# Altium schematic sheet Power.SchDoc
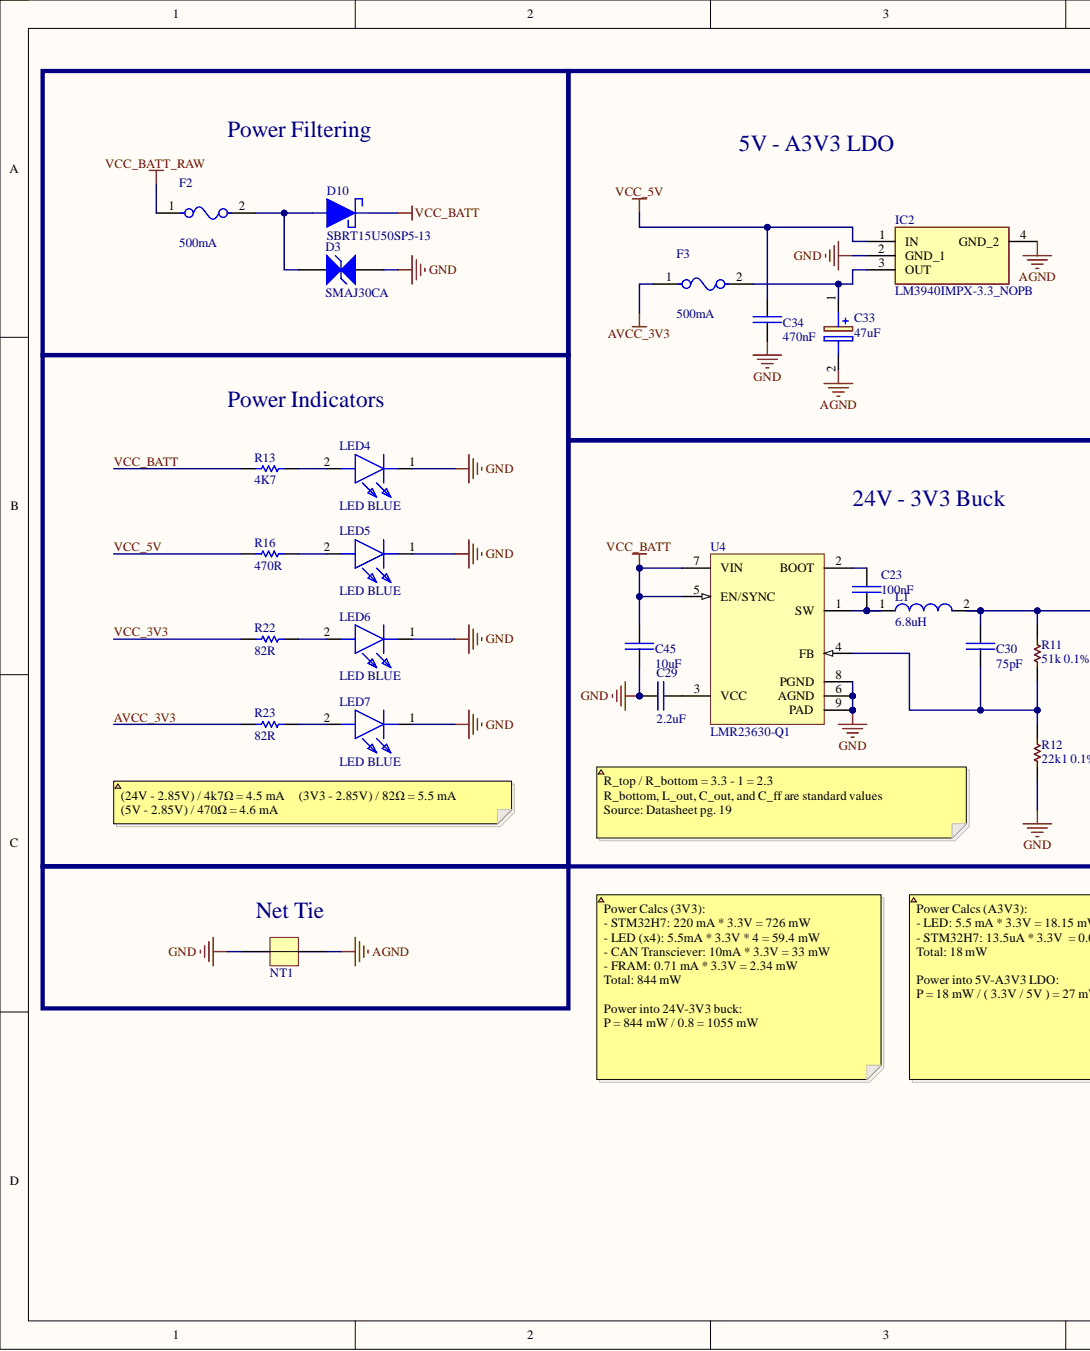
[diagram p0: Power.SchDoc, left]
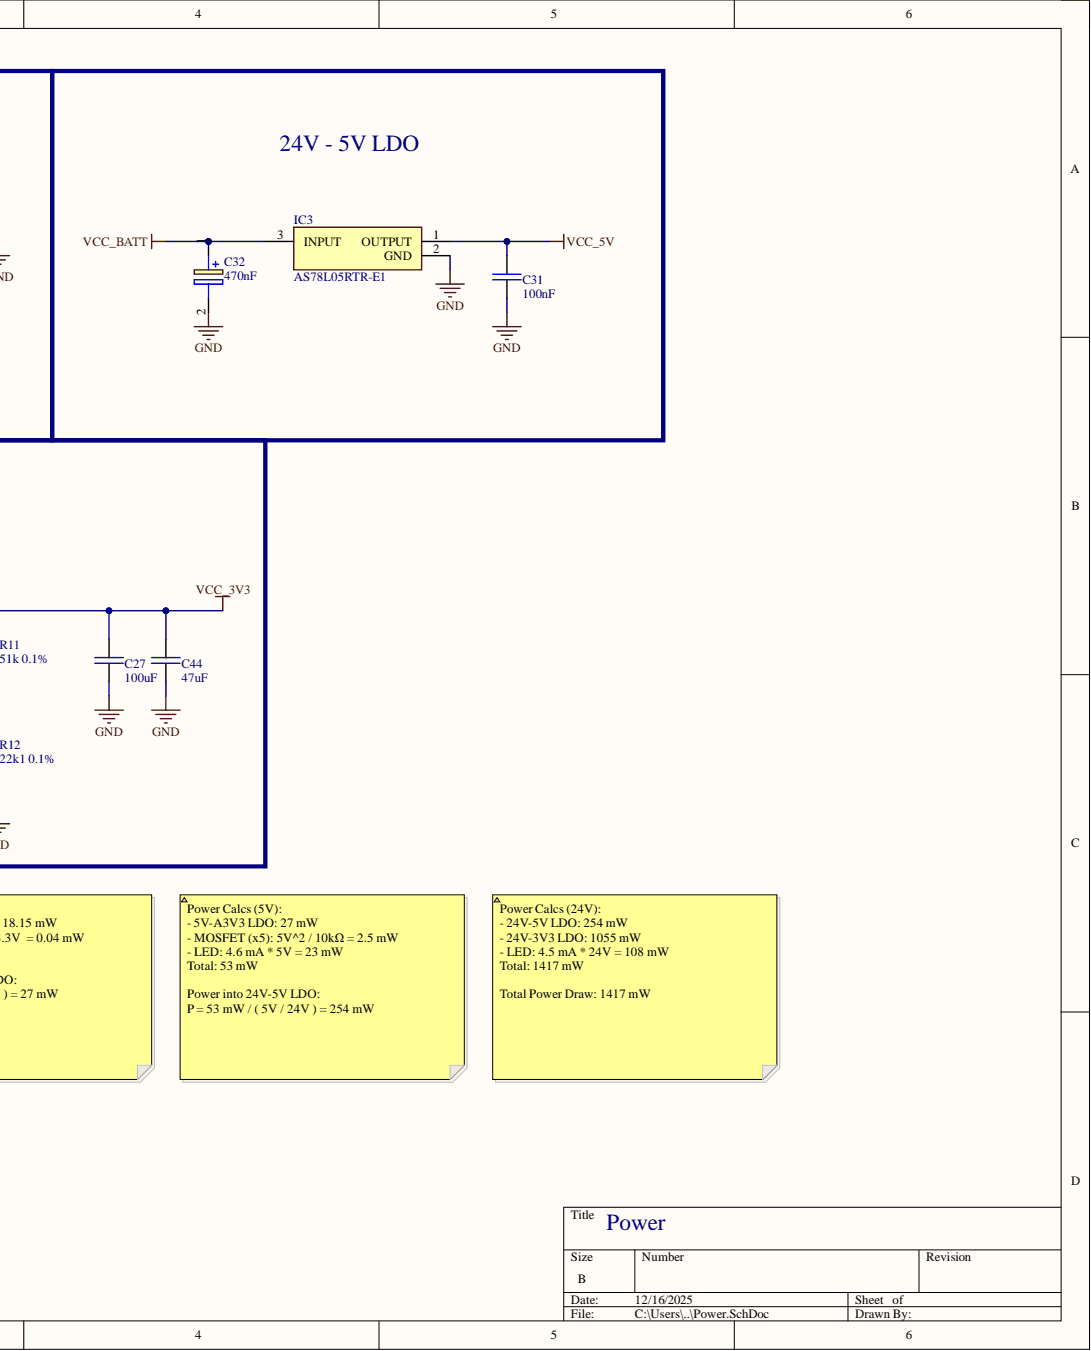
[diagram p1: Power.SchDoc, right]

## Parts
C23  100nF  [CAP_SM0603_YAGEOCC2_N]
C27  100uF  [FP-GRM31C-0_3-e0_3_0_8-IPC_A]
C29  2.2uF  [CAP_SM0603_YAGEOCC2_N]
C30  75pF  [CAP_SM0603_YAGEOCC2_N]
C31  100nF  [CAP_SM0603_YAGEOCC2_N]
C32  470nF  [CAPPM3216X180N]
C33  47uF  [CAPPM3216X180N]
C34  470nF  [CAP_SM0603_YAGEOCC2_N]
C44  47uF  [CAP_SM0603_YAGEOCC2_N]
C45  10uF  [CAP_SM0603_YAGEOCC2_N]
D3  SMAJ30CA  [LFUS-DO-214AC-A-2_V]
D10  SBRT15U50SP5-13  [SBRT15U50SP5-13]
F2  500mA  [FP-0466-MFG]
F3  500mA  [FP-0466-MFG]
IC2  LM3940IMPX-3.3_NOPB  [SOT230P700X180-4N]
IC3  AS78L05RTR-E1  [DIODES_SOT-89]
L1  6.8uH  [INDPM5752X300N]
LED4  LED BLUE  [LBQ99G01P1R1351]
LED5  LED BLUE  [LBQ99G01P1R1351]
LED6  LED BLUE  [LBQ99G01P1R1351]
LED7  LED BLUE  [LBQ99G01P1R1351]
NT1  Net Tie  [Net_Tie_80mil]
R11  51k 0.1%  [RES_SM0603_YAGEORC_N]
R12  22k1 0.1%  [RES_SM0603_YAGEORC_N]
R13  4K7  [RES_SM0603_YAGEORC_N]
R16  470R  [RES_SM0603_YAGEORC_N]
R22  82R  [RES_SM0603_YAGEORC_N]
R23  82R  [RES_SM0603_YAGEORC_N]
U4  LMR23630-Q1  [DDA0008E_N]

## Nets
AGND: C33.2 IC2.4(GND_2) NT1.2
GND: C27.2 C29.1 C31.2 C32.2 C34.2 C44.2 C45.2 D3.2 IC2.2(GND_1) IC3.2 LED4.1 LED5.1 LED6.1 LED7.1 NT1.1 R12.1 U4.6(AGND) U4.8(PGND) U4.9(PAD)
VCC_BATT_RAW: F2.1
AVCC_3V3: F3.1 R23.1
VCC_3V3: C27.1 C30.1 C44.1 L1.2 R11.2 R22.1
VCC_5V: C31.1 C34.1 IC2.1(IN) IC3.1 R16.1
VCC_BATT: C32.1 C45.1 D10.2 IC3.3 R13.1 U4.5(EN/SYNC) U4.7(VIN)
NetC23_1: C23.1 U4.2(BOOT)
NetC23_2: C23.2 L1.1 U4.1(SW)
NetC29_2: C29.2 U4.3(VCC)
NetC30_2: C30.2 R11.1 R12.2 U4.4(FB)
NetC33_1: C33.1 F3.2 IC2.3(OUT)
NetD3_1: D3.1 D10.1 F2.2
NetLED4_2: LED4.2 R13.2
NetLED5_2: LED5.2 R16.2
NetLED6_2: LED6.2 R22.2
NetLED7_2: LED7.2 R23.2

## Library data of the parts
C23 C31 = CC0603KRX7R9BB104: CAP 100nF SM0603 X7R 50V YAGEO CC2; Tolerance (%): 10; Voltage Rating (Volts): 50; Category: Capacitor; Component Kind: Standard; ComponentLink1Description: Manufacturer Website; ComponentLink1URL: http://www.yageo.com; Library Name: ResistorCapsInductors.IntLib; Manufacturer: Yageo; Manufacturer Part Number: CC0603KRX7R9BB104; Package: 0603; Supplier 1: Farnell; Supplier 2: Digi-Key; Supplier Part Number 1: 1362556; Supplier Part Number 2: 311-1344-1-ND; Value: 100nF
C27 = GRM31CR61A107MEA8L: Ceramic Capacitor, Multilayer, Ceramic, 10V, 20% +Tol, 20% -Tol, X5R, 15% TC, 100uF, Surface Mount, 1206; Manufacturer: Murata; Category: Ceramic Capacitors; Component Kind: Standard; ComponentLink1Description: https://datasheet.octopart.com/GRM31CR61A107MEA8L-Murata-datasheet-165579666.pdf?src-supplier=TME; ComponentLink1URL: https://datasheet.octopart.com/GRM31CR61A107MEA8L-Murata-datasheet-165579666.pdf?src-supplier=TME; Library Name: ResistorCapsInductors.IntLib; Manufacturer Part Number: GRM31CR61A107MEA8L; Pricing: 2000=0.1239, 10000=0.1122, 50000=0.0993 (USD); RoHS: Yes; RoHS Compliant: Yes; Stock: 6000; Supplier: Arrow Electronics; Supplier 1: DigiKey; Supplier Part Number: GRM31CR61A107MEA8L; Supplier Part Number 1: 490-GRM31CR61A107MEA8LCT-ND
C29 = CC0603KRX5R8BB225: CAP CER 2.2UF 25V X5R 0603; Manufacturer: Yageo; Manufacturer Part Number: CC0603KRX7R9BB183; Tolerance (%): 10; Voltage Rating (Volts): 50; Category: Capacitor; Component Kind: Standard; ComponentLink1Description: Manufacturer Website; ComponentLink1URL: http://www.yageo.com; Library Name: ResistorCapsInductors.IntLib; Package: 0603; Supplier 1: Farnell; Supplier 2: Digi-Key; Supplier Part Number 1: 3019743; Value: 2.2uF
C30 = CC0603JRNPO9BN750: CAP 75pF SM0603 NPO 50V YAGEO CC2; Manufacturer Part Number: CC0603JRNPO9BN820; Tolerance (%): 5; Voltage Rating (Volts): 50; Category: Capacitor; Component Kind: Standard; ComponentLink1Description: Manufacturer Website; ComponentLink1URL: http://www.yageo.com; Library Name: ResistorCapsInductors.IntLib; Manufacturer: Yageo; Package: 0603; Supplier 1: Farnell; Supplier 2: Digi-Key; Supplier Part Number 1: 722078; Supplier Part Number 2: 311-1068-1-ND; Value: 75pF
C32 = T491A474K035AT: 0.47 µF Molded Tantalum Capacitors 35 V 1206 (3216 Metric) 12Ohm; Manufacturer_Name: Kyocera AVX; Manufacturer_Part_Number: TLJA476M010R0600; Arrow Part Number: TLJA476M010R0600; Arrow Price/Stock: https://www.arrow.com/en/products/tlja476m010r0600/avx; Component Kind: Standard; Datasheet Link: https://componentsearchengine.com/Datasheets/1/TLJA476M010R0600.pdf; Height: 1.8mm; Library Name: ResistorCapsInductors.IntLib; Mouser Part Number: 581-TLJA476M010R0600; Mouser Price/Stock: https://www.mouser.co.uk/ProductDetail/AVX/TLJA476M010R0600?qs=o28%2FEEzBmj3NNm8C9jVwcw%3D%3D; Supplier 1: DigiKey; Supplier Part Number 1: 399-8287-1-ND
C33 = TLJA476M010R0600: 47uF 10V Tantalum Capacitor For LM3940IMPX-3.3_NOPB LDO; Manufacturer_Name: Kyocera AVX; Arrow Part Number: TLJA476M010R0600; Arrow Price/Stock: https://www.arrow.com/en/products/tlja476m010r0600/avx; Component Kind: Standard; Datasheet Link: https://componentsearchengine.com/Datasheets/1/TLJA476M010R0600.pdf; Height: 1.8mm; Library Name: ResistorCapsInductors.IntLib; Manufacturer_Part_Number: TLJA476M010R0600; Mouser Part Number: 581-TLJA476M010R0600; Mouser Price/Stock: https://www.mouser.co.uk/ProductDetail/AVX/TLJA476M010R0600?qs=o28%2FEEzBmj3NNm8C9jVwcw%3D%3D; Supplier 1: DigiKey; Supplier Part Number 1: 478-9009-1-ND
C34 = CC0603JRX7R8BB474: CAP 470nF SM0603 X7R 25V YAGEO CC2; Manufacturer Part Number: CC0603KRX7R9BB223; Tolerance (%): 10; Voltage Rating (Volts): 50; Category: Capacitor; Component Kind: Standard; ComponentLink1Description: Manufacturer Website; ComponentLink1URL: http://www.yageo.com; Library Name: ResistorCapsInductors.IntLib; Manufacturer: Yageo; Package: 0603; Supplier 1: Farnell; Supplier 2: Digi-Key; Supplier Part Number 1: 3019755; Supplier Part Number 2: 311-1370-1-ND; Value: 470nF
C44 = CC0603MRX5R4BB476: CAP 47uF SM0603 X5R 4V YAGEO CC2; Manufacturer Part Number: CC0603KRX7R9BB223; Tolerance (%): 10; Voltage Rating (Volts): 50; Category: Capacitor; Component Kind: Standard; ComponentLink1Description: Manufacturer Website; ComponentLink1URL: http://www.yageo.com; Library Name: ResistorCapsInductors.IntLib; Manufacturer: Yageo; Package: 0603; Supplier 1: Farnell; Supplier 2: Digi-Key; Supplier Part Number 1: 3019755; Supplier Part Number 2: 311-1370-1-ND; Value: 47uF
C45 = GRM188R6YA106MA73D: Ceramic Capacitor, Multilayer, Ceramic, 35V, 20% +Tol, 20% -Tol, X5R, 15% TC, 10uF, Surface Mount, 0603; Manufacturer: Murata; Multilayer: Yes; Capacitor Type: Ceramic; Case/Package: 0603; Category: Ceramic Capacitors; Component Kind: Standard; ComponentLink1Description: https://datasheet.ciiva.com:443/pdfs/VipMasterIC/IC/MURE/MURE-S-A0004349797/MURE-S-A0004352457-1.pdf?src-supplier=IHS+Markit; ComponentLink1URL: https://datasheet.ciiva.com:443/pdfs/VipMasterIC/IC/MURE/MURE-S-A0004349797/MURE-S-A0004352457-1.pdf?src-supplier=IHS+Markit; ComponentLink2Description: http://search.murata.co.jp/Ceramy/image/img/A01X/G101/ENG/GRM188R6YA106MA73-01.pdf?src-supplier=Digikey; ComponentLink2URL: http://search.murata.co.jp/Ceramy/image/img/A01X/G101/ENG/GRM188R6YA106MA73-01.pdf?src-supplier=Digikey; ComponentLink3Description: https://www.murata.com/~/media/webrenewal/support/library/catalog/products/capacitor/mlcc/c02e.ashx?la=en-us&src-supplier=Digikey; ComponentLink3URL: https://www.murata.com/~/media/webrenewal/support/library/catalog/products/capacitor/mlcc/c02e.ashx?la=en-us&src-supplier=Digikey; ComponentLink4Description: https://datasheet.octopart.com/GRM188R6YA106MA73D-Murata-datasheet-149523261.pdf?src-supplier=Newark; ComponentLink4URL: https://datasheet.octopart.com/GRM188R6YA106MA73D-Murata-datasheet-149523261.pdf?src-supplier=Newark; ComponentLink5Description: https://datasheet.octopart.com/GRM188R6YA106MA73D-Murata-datasheet-86813743.pdf?src-supplier=Future+Electronics; ComponentLink5URL: https://datasheet.octopart.com/GRM188R6YA106MA73D-Murata-datasheet-86813743.pdf?src-supplier=Future+Electronics; ComponentLink6Description: http://search.murata.co.jp/Ceramy/image/img/A01X/G101/ENG/GRM188R6YA106MA73-01.pdf?src-supplier=Future+Electronics; ComponentLink6URL: http://search.murata.co.jp/Ceramy/image/img/A01X/G101/ENG/GRM188R6YA106MA73-01.pdf?src-supplier=Future+Electronics; ComponentLink7Description: http://search.murata.co.jp/Ceramy/image/img/A01X/G101/ENG/GRM188R6YA106MA73-01.pdf?src-supplier=Mouser; ComponentLink7URL: http://search.murata.co.jp/Ceramy/image/img/A01X/G101/ENG/GRM188R6YA106MA73-01.pdf?src-supplier=Mouser; ComponentLink8Description: http://search.murata.co.jp/Ceramy/image/img/A01X/G101/ENG/GRM188R6YA106MA73-01.pdf?src-supplier=TTI; ComponentLink8URL: http://search.murata.co.jp/Ceramy/image/img/A01X/G101/ENG/GRM188R6YA106MA73-01.pdf?src-supplier=TTI; ComponentLink9Description: https://datasheet.octopart.com/GRM188R6YA106MA73D-Murata-datasheet-165579666.pdf?src-supplier=TME; ComponentLink9URL: https://datasheet.octopart.com/GRM188R6YA106MA73D-Murata-datasheet-165579666.pdf?src-supplier=TME; Dielectric Material: Ceramic; JESD-609 Code: e3; Library Name: ResistorCapsInductors.IntLib; Manufacturer Part Number: GRM188R6YA106MA73D; Max Operating Temperature: 85°C; Min Operating Temperature: -55°C; Mounting Technology: Surface Mount; Package Shape: Rectangular; Packaging: Tape and Reel; Pins: 2; Pricing: 4000=0.0516, 8000=0.043, 24000=0.0355 (USD); Rated DC Voltage (URdc): 35V; Reach SVHC Compliant: Yes; RoHS: Yes; RoHS Compliant: Yes; Stock: 56000; Supplier: Arrow Electronics; Supplier Part Number: GRM188R6YA106MA73D; Temperature Characteristics Code: X5R; Terminal Finish: Nickel; Termination: Wraparound; Tolerance  (fill in as +-): 20%; Tolerance (fill in as +-): 20%; Value: 10uF
D3 = SMAJ30CA: Transient Voltage Suppression Diode, 30 V, -65 to 150 degC, 2-Pin SMD, RoHS, Tape and Reel; Manufacturer: Littelfuse-Inc.; Bidirectional Channels: 1; Current - Peak Pulse (10/1000µs): 8.3A; Power - Peak Pulse: 400W; Power Line Protection: No; Type: Zener; Voltage - Breakdown (Min): 33.3V; Voltage - Clamping (Max) @ Ipp: 48.4V; Voltage - Reverse Standoff (Typ): 30V; Applications: GeneralPurpose; Capacitance @ Frequency: -; Category: CircuitProtection; Component Kind: Standard; Datasheet URL: http://www.littelfuse.com/~/media/electronics/datasheets/tvs_diodes/littelfuse_tvs_diode_smaj_datasheet.pdf.pdf; Datasheet Version: 11/2015; Family: TVS-Diodes; Library Name: DiodesAndFuses.IntLib; Manufacturer Part Number: SMAJ30CA; Manufacturer URL: http://www.littelfuse.com/; Mounting Technology: SurfaceMount; Mounting Type: SurfaceMount; Operating Temperature: -55°C~150°C(TJ); Package / Case: DO-214AC,SMA; Package Description: 2-Pin Surface Mount Device, Body 4.295 x 2.595 mm; Package Reference: DO-214AC; Packaging: Digi-Reel®; Series: SMAJ; Supplier 1: DigiKey; Supplier Device Package: DO-214AC(SMA); Supplier Part Number 1: SMAJ30CALFDKR-ND; Unidirectional Channels: -
D10 = SBRT15U50SP5-13: Reverse Polarity Protection; Manufacturer: Diodes Incorporated; Current - Average Rectified (Io): 15A; Diode Type: Super Barrier; Speed: Fast Recovery =< 500ns, > 200mA (Io); Capacitance @ Vr, F: -; Category: Discrete Semiconductor Products; Component Kind: Standard; ComponentLink1Description: https://media.digikey.com/pdf/Data%20Sheets/Diodes%20PDFs/SBRT15U50SP5.pdf; ComponentLink1URL: https://media.digikey.com/pdf/Data%20Sheets/Diodes%20PDFs/SBRT15U50SP5.pdf; Library Name: DiodesAndFuses.IntLib; Manufacturer Part Number: SBRT15U50SP5-13; Mounting Type: Surface Mount; Operating Temperature - Junction: -65°C ~ 150°C; Package / Case: PowerDI™ 5; Packaging: Cut Tape (CT); Part Status: Active; Pricing 1: 1=0.93, 10=0.823, 100=0.6306, 500=0.49852, 1000=0.39882 (USD); RoHS: RoHS Compliant; Stock 1: 12134; Supplier 1: Digi-Key; Supplier Device Package: PowerDI®5; Supplier Part Number 1: SBRT15U50SP5-13DICT-ND
F2 F3 = 0466.500NR: 500mA Fuse; Manufacturer: Littelfuse Inc; Fuse Blow Speed: Fast; Fuse Current: 0.5A; Fuse I2T: 0.006A2Sec; Fuse Interrupt Ac Current: 50A; Fuse Voltage Drop: 158.47mV; Max Fuse Ac Voltage: 63V; Resistance: 0.248Ω; Value: 0.248Ω; Automotive: No; Category: Fuse; Component Kind: Standard; ComponentLink2Description: Datasheet; ComponentLink2URL: https://www.littelfuse.com/~/media/electronics/datasheets/fuses/littelfuse_fuse_466_datasheet.pdf.pdf; Device Class L1: Circuit Protection; Device Class L2: Fuses; Device Class L3: unset; Digikey Description: FUSE BOARD MOUNT 500MA 63VAC/VDC; Fuse Interrupt Dc Current: 50A; Height: 0.66mm; Lead Free: Yes; Library Name: DiodesAndFuses.IntLib; Manufacturer Part Number: 0466.500NR; Max Fuse Dc Voltage: 63V; Mouser Description: SMD Fuse 0.5 A Fast-Blow, 466 1206; Octopart: https://octopart.com/0466.500nr-littelfuse-566004; Package: 0466; RoHS: TRUE; Temperature: -55°C to +90°C; Temperature Range High: +90°C; Temperature Range Low: -55°C; Voltage Rating: 63V
IC2 = LM3940IMPX-3.3_NOPB: 3V3 LDO; Manufacturer_Name: Texas Instruments; Manufacturer_Part_Number: LM3940IMPX-3.3/NOPB; Arrow Part Number: LM3940IMPX-3.3/NOPB; Arrow Price/Stock: https://www.arrow.com/en/products/lm3940impx-3.3nopb/texas-instruments?region=nac; Component Kind: Standard; Datasheet Link: http://www.ti.com/lit/ds/symlink/lm3940.pdf; Height: 1.8mm; Library Name: Power.IntLib; Mouser Part Number: 926-LM3940IMPX33NOPB; Mouser Price/Stock: https://www.mouser.co.uk/ProductDetail/Texas-Instruments/LM3940IMPX-3.3-NOPB?qs=QbsRYf82W3FUgXR%252BoLZPCw%3D%3D; Supplier 1: DigiKey; Supplier Part Number 1: LM3940IMPX-3.3/NOPBCT-ND
IC3 = AS78L05RTR-E1: Integrated Circuit; Manufacturer_Name: Diodes Incorporated; Arrow Part Number: AS78L05RTR-E1; Arrow Price/Stock: https://www.arrow.com/en/products/as78l05rtr-e1/diodes-incorporated?utm_currency=USD&region=europe; Component Kind: Standard; Datasheet Link: https://www.diodes.com/assets/Datasheets/AS78Lxx.pdf; Manufacturer_Part_Number: AS78L05RTR-E1; Mouser Part Number: 621-AS78L05RTR-E1; Mouser Price/Stock: https://www.mouser.co.uk/ProductDetail/Diodes-Incorporated/AS78L05RTR-E1?qs=FKu9oBikfSksfBIasZ7FtQ%3D%3D; Supplier 1: DigiKey; Supplier Part Number 1: AS78L05RTR-E1DICT-ND
L1 = SRP5030TA-6R8M: 6.8uH Inductor; Manufacturer_Name: Bourns; Arrow Part Number: SRP5030TA-6R8M; Arrow Price/Stock: https://www.arrow.com/en/products/srp5030ta-6r8m/bourns?utm_currency=USD&region=nac; Component Kind: Standard; Datasheet Link: https://www.bourns.com/docs/Product-Datasheets/SRP5030TA.pdf; Height: 3mm; Library Name: ResistorCapsInductors.IntLib; Manufacturer_Part_Number: SRP5030TA-6R8M; Mouser Part Number: 652-SRP5030TA-6R8M; Mouser Price/Stock: https://www.mouser.co.uk/ProductDetail/Bourns/SRP5030TA-6R8M?qs=ZwKJWZfDtNjq9Vu0UutxmQ%3D%3D; Supplier 1: DigiKey; Supplier Part Number 1: SRP5030TA-6R8MCT-ND
LED4-LED7 = LB Q99G.01-P1R1-35-1-5-R18: LED Blue 2.85V 5mA USE THIS ONE; Manufacturer_Name: ams OSRAM; Component Kind: Standard; Datasheet Link: https://look.ams-osram.com/m/5d39d28026a1abba/original/LB-Q99G-01.pdf; Height: 0.75mm; Library Name: DiodesAndFuses.IntLib; Manufacturer_Part_Number: LB Q99G.01-P1R1-35-1; Mouser Part Number: 720-LBQ99G01P1R135; Mouser Price/Stock: https://www.mouser.co.uk/ProductDetail/ams-OSRAM/LB-Q99G.01-P1R1-35-1?qs=IPgv5n7u5QZHWhEkNg3xgA%3D%3D
NT1 = Net Tie: Use to tie together nets; Component Kind: Net Tie; Library Name: Misc.IntLib
R11 = RT0603BRE0751KL: RES 51K 0.1% SM0603 YAGEO RC_L; Manufacturer Part Number: RC0603FR-071KL; Power (Watts): 0.1; Resistance (Ohms): 1000; Tolerance (%): 1; Value: 1K; Category: Resistor; Component Kind: Standard; ComponentLink1Description: Manufacturer Website; ComponentLink1URL: http://www.yageo.com; Library Name: ResistorCapsInductors.IntLib; Manufacturer: Yageo; Package: 0603; Supplier 1: Digikey; Supplier Part Number 1: YAG4750CT-ND
R12 = RT0603BRD0722K1L: RES 22K1 0.1% SM0603 YAGEO RC_L; Manufacturer Part Number: RC0603FR-071KL; Power (Watts): 0.1; Resistance (Ohms): 1000; Tolerance (%): 1; Value: 1K; Category: Resistor; Component Kind: Standard; ComponentLink1Description: Manufacturer Website; ComponentLink1URL: http://www.yageo.com; Library Name: ResistorCapsInductors.IntLib; Manufacturer: Yageo; Package: 0603; Supplier 1: Digikey; Supplier Part Number 1: YAG1590CT-ND
R13 = RC0603FR-074K7L: RES 4K7 SM0603 YAGEO RC_L; Power (Watts): 0.1; Resistance (Ohms): 4700; Tolerance (%): 1; Category: Resistor; Component Kind: Standard; ComponentLink1Description: Manufacturer Website; ComponentLink1URL: http://www.yageo.com; Library Name: ResistorCapsInductors.IntLib; Manufacturer: Yageo; Manufacturer Part Number: RC0603FR-074K7L; Package: 0603; Supplier 1: Farnell; Supplier 2: Digi-Key; Supplier Part Number 1: 9238565; Supplier Part Number 2: 311-4.70KHRCT-ND; Value: 4K7
R16 = RC0603FR-07470RL: RES 470R SM0603 YAGEO RC_L; Power (Watts): 0.1; Resistance (Ohms): 470; Tolerance (%): 1; Category: Resistor; Component Kind: Standard; ComponentLink1Description: Manufacturer Website; ComponentLink1URL: http://www.yageo.com; Library Name: ResistorCapsInductors.IntLib; Manufacturer: Yageo; Manufacturer Part Number: RC0603FR-07470RL; Package: 0603; Supplier 1: Farnell; Supplier 2: Digi-Key; Supplier Part Number 1: 2421875; Supplier Part Number 2: 311-470HRCT-ND; Value: 470R
R22 R23 = RC0603FR-0782RL: RES 82R SM0603 YAGEO RC_L; Power (Watts): 0.1; Resistance (Ohms): 82; Tolerance (%): 1; Category: Resistor; Component Kind: Standard; ComponentLink1Description: Manufacturer Website; ComponentLink1URL: http://www.yageo.com; Library Name: ResistorCapsInductors.IntLib; Manufacturer: Yageo; Manufacturer Part Number: RC0603FR-0782RL; Package: 0603; Supplier 1: Farnell; Supplier 2: Digi-Key; Supplier Part Number 1: 9238352; Supplier Part Number 2: 311-82.0HRCT-ND; Value: 82R
U4 = LMR23630-Q1: Buck Converter; Manufacturer 1: Texas Instruments; Manufacturer Part Number 1: LMR23630AFQDDARQ1; Component Kind: Standard; Library Name: Power.IntLib; Max Operating Temperature: 125°C; Min Operating Temperature: -40°C; Pricing 1: 1=3.97, 10=3.564, 100=2.9204, 500=2.486, 1000=2.0967 (USD); Stock 1: 2872; Supplier 1: Digi-Key; Supplier Part Number 1: 296-45260-1-ND
